# Altium schematic sheet DC Motor Driver 1.1.SchDoc("DC Motor Driver 1.1")
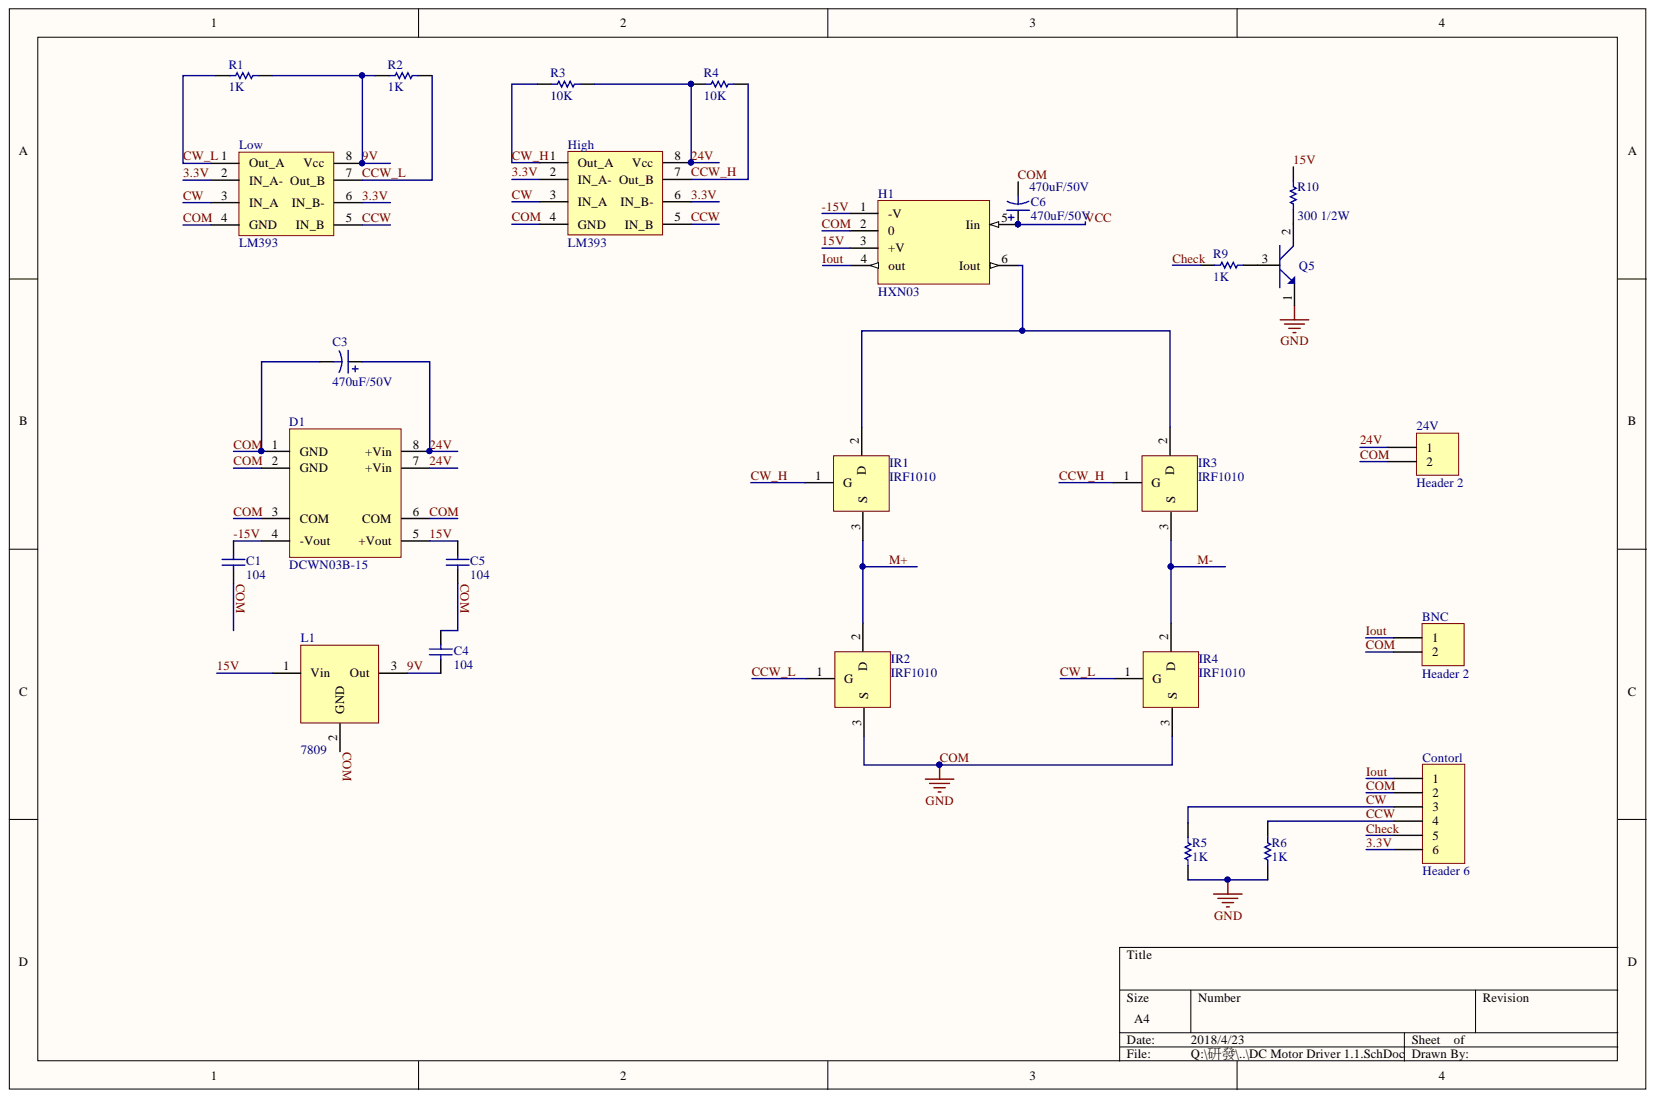
[diagram p0: DC Motor Driver 1.1.SchDoc]

## Parts
24V  Header 2  [HDR1X2]
BNC  Header 2  [HDR1X2]
C1  104  [RAD-0.1]
C3  470uF/50V  [RB5-10.5]
C4  104  [RAD-0.1]
C5  104  [RAD-0.1]
C6  470uF/50V  [RB5-10.5]
Contorl  Header 6  [HDR1X6]
D1  DCWN03B-15  [S/D CWN03B]
H1  HXN03  [HXN03 10]
High  LM393  [DIP8]
IR1  IRF1010  [TO-220-AB]
IR2  IRF1010  [TO-220-AB]
IR3  IRF1010  [TO-220-AB]
IR4  IRF1010  [TO-220-AB]
L1  7809  [78xx]
Low  LM393  [DIP8]
Q5  C2120  [C2120]  (value not drawn)
R1  1K  [AXIAL-0.3]
R2  1K  [AXIAL-0.3]
R3  10K  [AXIAL-0.3]
R4  10K  [AXIAL-0.3]
R5  1K  [AXIAL-0.3]
R6  1K  [AXIAL-0.3]
R9  1K  [AXIAL-0.3]
R10  300 1/2W  [AXIAL-0.4]

## Nets
3.3V: Contorl.6 High.2(IN_A-) High.6(IN_B-) Low.2(IN_A-) Low.6(IN_B-)
9V: C4.1 L1.3 Low.8(Vcc) R1.2 R2.1
15V: C5.2 D1.5(+Vout) H1.3(+V) L1.1 R10.2
24V: 24V.1 C3.1 D1.7(+Vin) D1.8(+Vin) High.8(Vcc) R3.2 R4.1
-15V: C1.2 D1.4(-Vout) H1.1(-V)
CCW: Contorl.4 High.5(IN_B) Low.5(IN_B) R6.2
CCW_H: High.7(Out_B) IR3.1 R4.2
CCW_L: IR2.1 Low.7(Out_B) R2.2
CHECK: Contorl.5 R9.1
COM: 24V.2 BNC.2 C1.1 C3.2 C4.2 C5.1 C6.2 Contorl.2 D1.1(GND) D1.2(GND) D1.3(COM) D1.6(COM) H1.2 High.4(GND) IR2.3 IR4.3 L1.2 Low.4(GND) Q5.1 R5.1 R6.1
CW: Contorl.3 High.3(IN_A) Low.3(IN_A) R5.2
CW_H: High.1(Out_A) IR1.1 R3.1
CW_L: IR4.1 Low.1(Out_A) R1.1
IOUT: BNC.1 Contorl.1 H1.4(out)
M+: IR1.3 IR2.2
M-: IR3.3 IR4.2
VCC: C6.1 H1.5(Iin)
NetH1_6: H1.6(Iout) IR1.2 IR3.2
NetQ5_2: Q5.2 R10.1
NetQ5_3: Q5.3 R9.2

## Library data of the parts
24V BNC = Header 2: Header, 2-Pin; Component Kind: Standard; LatestRevisionDate: 17-Jul-2002; LatestRevisionNote: Re-released for DXP Platform.; Library Name: Miscellaneous Connectors.IntLib; Signal Integrity: Connector; Publisher: Altium Limited
C1 C4 C5 = Cap: Capacitor; Component Kind: Standard; LatestRevisionDate: 17-Jul-2002; LatestRevisionNote: Re-released for DXP Platform.; Library Name: Miscellaneous Devices.IntLib; PackageDescription: Radial Cap, Thru-Hole; 2 Leads; 0.3 in Pin Spacing; PackageReference: RAD-0.3; Published: 8-Jun-2000; Signal Integrity: Cap; Simulation: CAP; Value: 104; Publisher: Altium Limited
C3 C6 = Cap Pol1: Polarized Capacitor (Radial); Component Kind: Standard; LatestRevisionDate: 17-Jul-2002; LatestRevisionNote: Re-released for DXP Platform.; Library Name: Miscellaneous Devices.IntLib; PackageDescription: Polarized Capacitor (Radial); 2 Leads; PackageReference: RB7.6-15; Published: 8-Jun-2000; Signal Integrity: Cap Pol; Simulation: CAP; Value: 470uF/50V; Publisher: Altium Limited
Contorl = Header 6: Header, 6-Pin; Component Kind: Standard; LatestRevisionDate: 17-Jul-2002; LatestRevisionNote: Re-released for DXP Platform.; Library Name: Miscellaneous Connectors.IntLib; Signal Integrity: Connector; Publisher: Altium Limited
D1 = DCWN03B-15: DC-DC regulated convertor +-15V  (input 18V~36V); Component Kind: Standard; Library Name: Power.SchLib
H1 = HXN03: current sensor 3A; Component Kind: Standard; Library Name: current_sensor.SchLib
L1 = 7806: 9V; Component Kind: Standard; Library Name: Power.SchLib
R1-R6 R9 R10 = Res1: Resistor; Component Kind: Standard; LatestRevisionDate: 17-Jul-2002; LatestRevisionNote: Re-released for DXP Platform.; Library Name: Miscellaneous Devices.IntLib; PackageDescription: Axial Device, Thru-Hole; 2 Leads; 0.3 in Pin Spacing; PackageReference: AXIAL-0.3; Published: 8-Jun-2000; Signal Integrity: Res; Simulation: RESISTOR; Publisher: Altium Limited
  R1 R2 R5 R6 R9: Value: 1K
  R3 R4: Value: 10K
  R10: Value: 300 1/2W
High Low = LM393: Component Kind: Standard; Library Name: 比較器.SchLib
IR1-IR4 = IRF1010: Component Kind: Standard; Library Name: NPN_MOS.SchLib
Q5 = C2120: Component Kind: Standard; Library Name: NPN.SchLib
